# Altium schematic sheet Sheet2.SchDoc
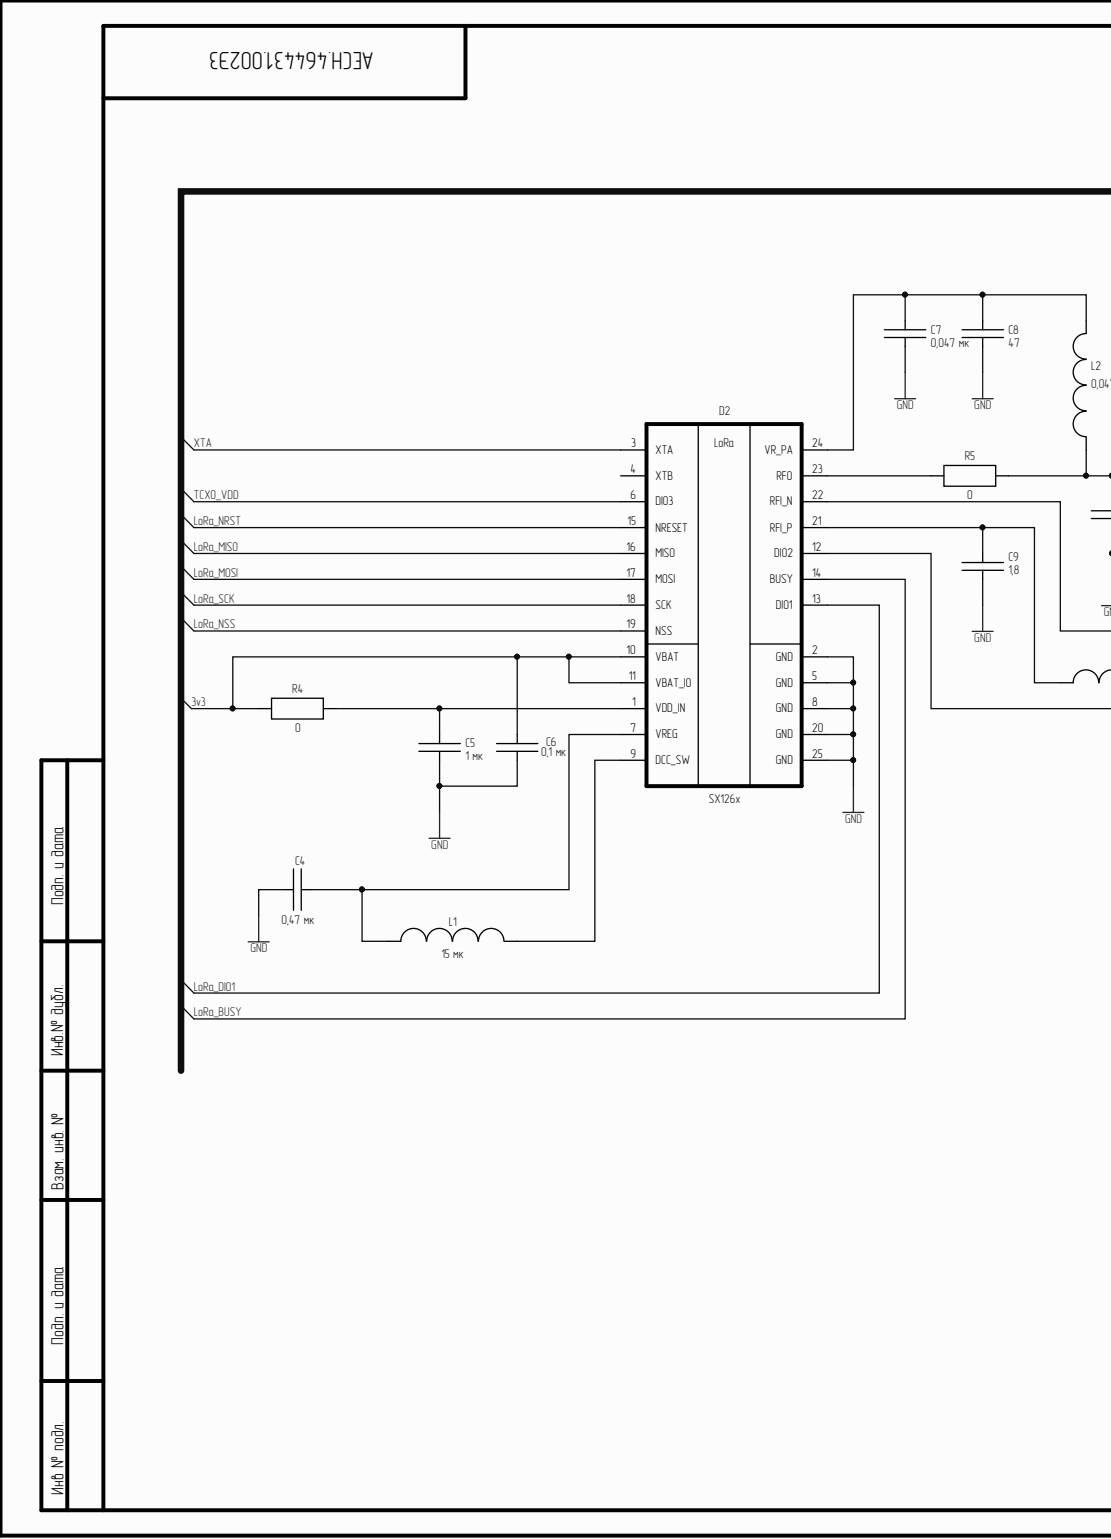
[diagram p0: Sheet2.SchDoc, left]
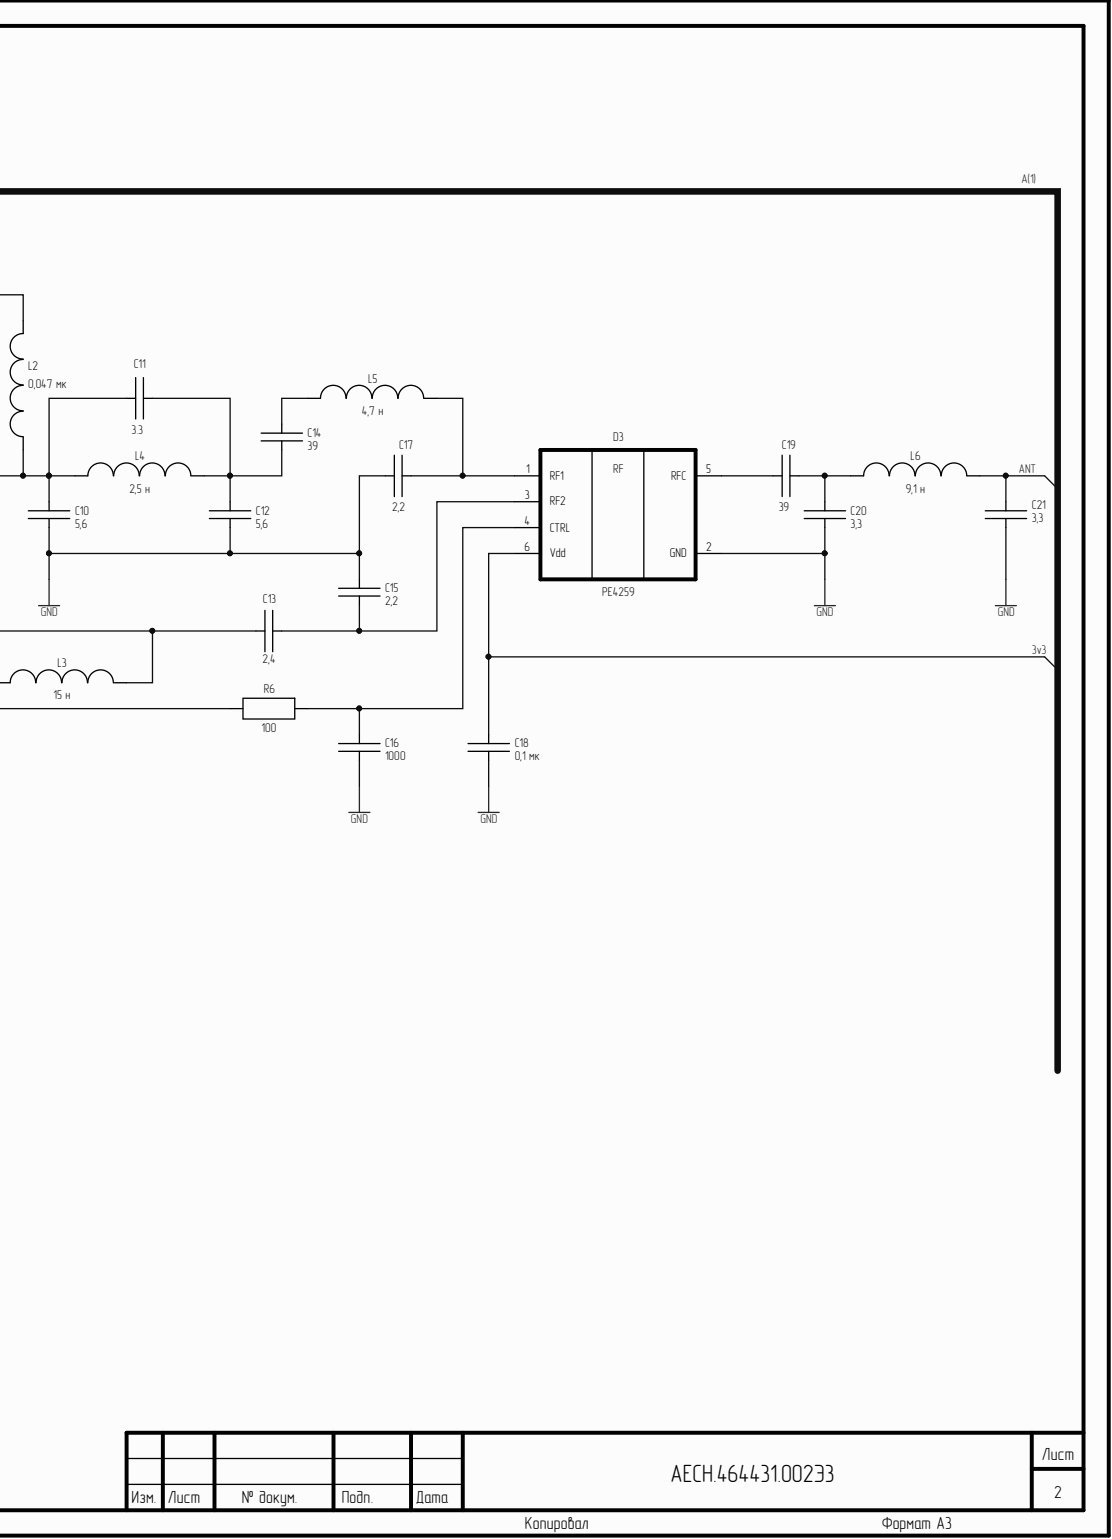
[diagram p1: Sheet2.SchDoc, right]

## Parts
C4  C  value 0,47 мк  [С0603]
C5  C  value 1 мк  [С0603]
C6  C  value 0,1 мк  [С0603]
C7  C  value 0,047 мк  [С0603]
C8  C  value 47  [С0603]
C9  C  value 1,8  [С0603]
C10  C  value 5,6  [С0603]
C11  C  value 3.3  [С0603]
C12  C  value 5,6  [С0603]
C13  C  value 2,4  [С0603]
C14  C  value 39  [С0603]
C15  C  value 2,2  [С0603]
C16  C  value 1000  [С0603]
C17  C  value 2,2  [С0603]
C18  C  value 0,1 мк  [С0603]
C19  C  value 39  [С0603]
C20  C  value 3,3  [С0603]
C21  C  value 3,3  [С0603]
D2  SX126x  [QFN24_4X4_SEM]
D3  PE4259  [SC70-6]
L1  L  value 15 мк  [IND_0805]
L2  L  value 0,047 мк  [INDC_0603]
L3  L  value 15 н  [INDC_0603]
L4  L  value 2,5 н  [INDC_0603]
L5  L  value 4,7 н  [INDC_0603]
L6  L  value 9,1 н  [INDC_0603]
R4  R  value 0  [R0603]
R5  R  value 0  [R0603]
R6  R  value 100  [R0603]

## Nets
GND: C4.1 C5.2 C6.2 C7.2 C8.2 C9.2 C10.2 C12.2 C15.1 C16.2 C17.1 C18.2 C20.2 C21.2 D2.2(GND) D2.5(GND) D2.8(GND) D2.20(GND) D2.25(GND) D3.2(GND)
3v3: C6.1 C18.1 D2.10(VBAT) D2.11(VBAT_IO) D3.6(Vdd) R4.1
ANT: C21.1 L6.2
LoRa_BUSY: D2.14(BUSY)
LoRa_DIO1: D2.13(DIO1)
LoRa_MISO: D2.16(MISO)
LoRa_MOSI: D2.17(MOSI)
LoRa_NRST: D2.15(NRESET)
LoRa_NSS: D2.19(NSS)
LoRa_SCK: D2.18(SCK)
TCXO_VDD: D2.6(DIO3)
XTA: D2.3(XTA)
NetC4_2: C4.2 D2.7(VREG) L1.1
NetC5_1: C5.1 D2.1(VDD_IN) R4.2
NetC7_1: C7.1 C8.1 D2.24(VR_PA) L2.2
NetC9_1: C9.1 D2.21(RFI_P) L3.1
NetC10_1: C10.1 C11.1 L2.1 L4.1 R5.2
NetC11_2: C11.2 C12.1 C14.1 L4.2
NetC13_1: C13.1 D2.22(RFI_N) L3.2
NetC13_2: C13.2 C15.2 D3.3(RF2)
NetC14_2: C14.2 L5.1
NetC16_1: C16.1 D3.4(CTRL) R6.2
NetC17_2: C17.2 D3.1(RF1) L5.2
NetC19_1: C19.1 D3.5(RFC)
NetC19_2: C19.2 C20.1 L6.1
NetD2_9: D2.9(DCC_SW) L1.2
NetD2_12: D2.12(DIO2) R6.1
NetD2_23: D2.23(RFO) R5.1
A(1):
N00082:

Not connected: D2 4(XTB)

## Library data of the parts
C4 C5 C16 = C: Voltage: 10 В; Component Kind: Standard; Library Name: Schlib1.SchLib
C6 C18 = C: Voltage: 16 В; Component Kind: Standard; Library Name: Schlib1.SchLib
C7 = C: Value: 0,047 мк; Voltage: 16 В; Component Kind: Standard; Library Name: Schlib1.SchLib
C8-C11 C13-C15 C17 C19-C21 = C: Voltage: 50 В; Component Kind: Standard; Library Name: Schlib1.SchLib
C12 = C: Voltage: 50; Component Kind: Standard; Library Name: Schlib1.SchLib
D2 = SX126x: Component Kind: Standard; Library Name: Schlib1.SchLib
D3 = PE4259: Component Kind: Standard; Library Name: Schlib1.SchLib
L1-L6 = L: Component Kind: Standard; Library Name: Schlib1.SchLib
R4-R6 = R: Component Kind: Standard; Library Name: Schlib1.SchLib
